# Altium schematic sheet LiPoBattery.SchDoc(LiPoBattery)
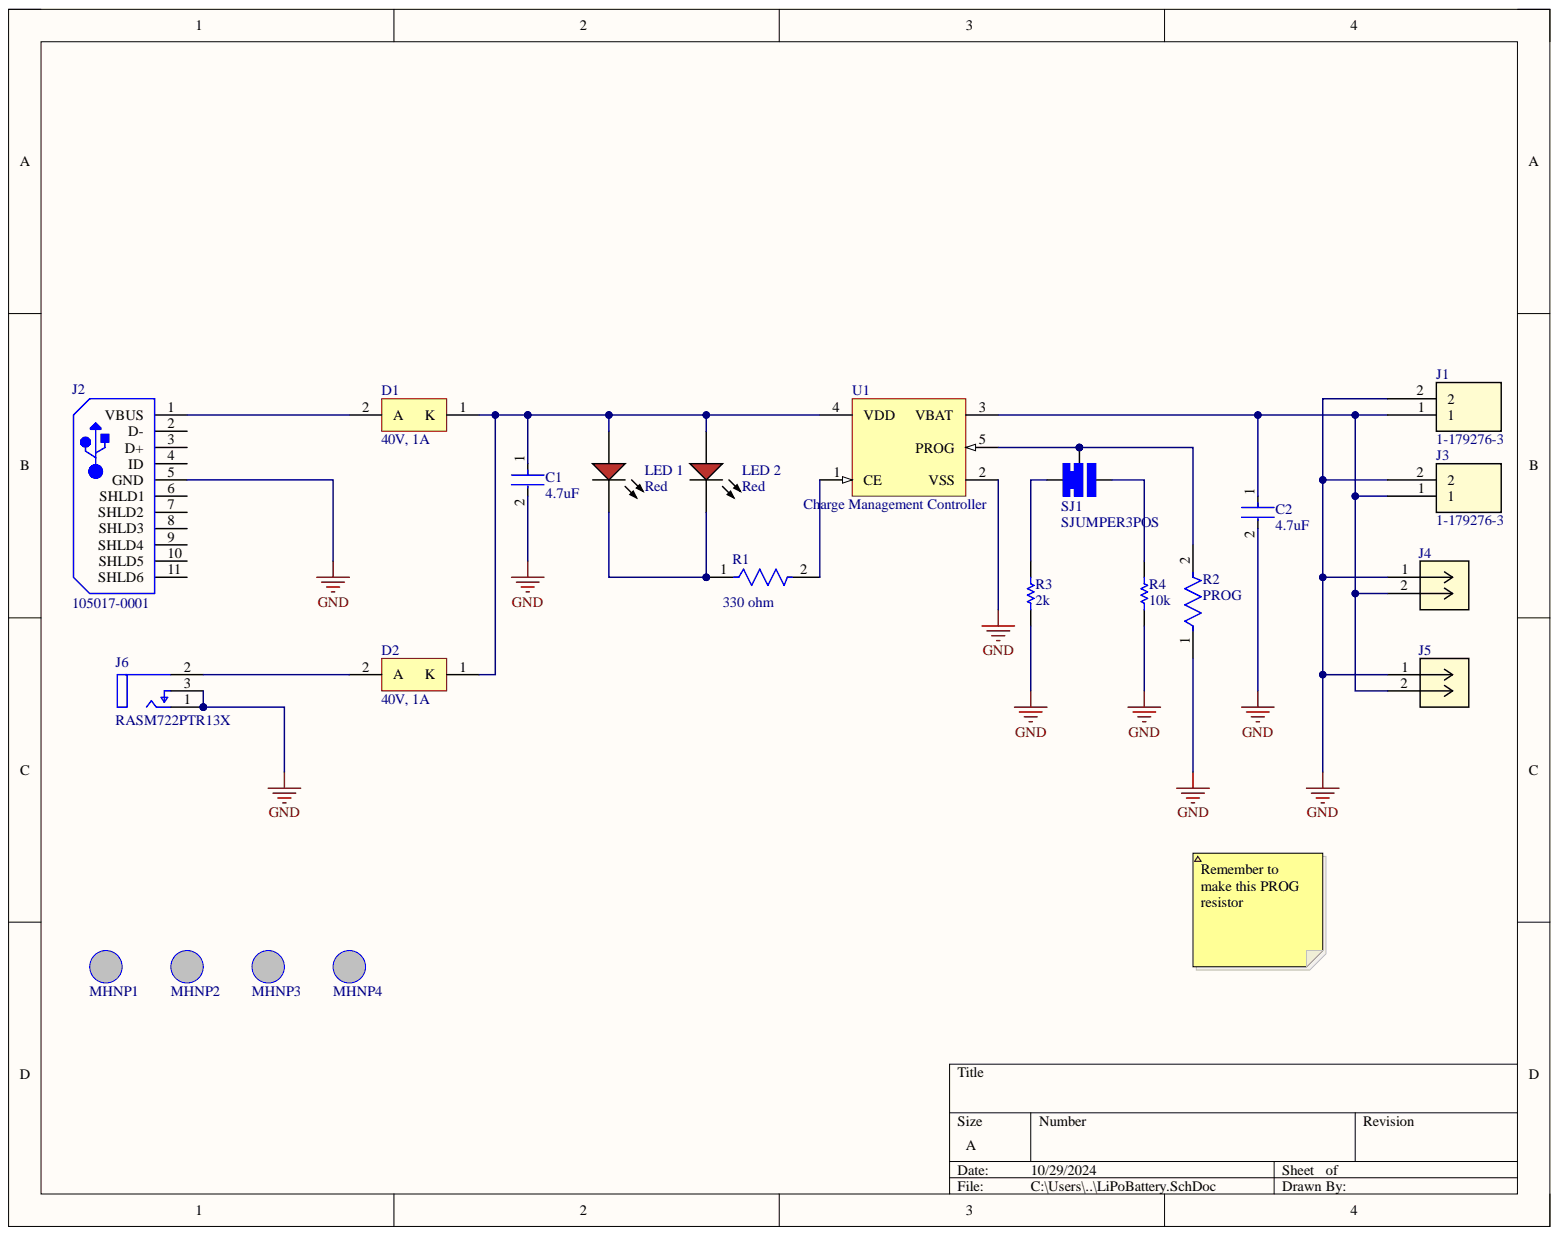
[diagram p0: LiPoBattery.SchDoc]

## Parts
C1  4.7uF  [FP-CC0402-MFG]
C2  4.7uF  [FP-CC0402-MFG]
D1  40V, 1A  [ONSC-SMA-2-403D-02_V]
D2  40V, 1A  [ONSC-SMA-2-403D-02_V]
J1  1-179276-3  [1-179276-3_TYC]
J2  105017-0001  [USB-MICRO-B_V]
J3  1-179276-3  [1-179276-3_TYC]
J4  2POS VET HEADER 0.100*  [2Pins_AMP]  (value not drawn)
J5  2POS VET HEADER 0.100*  [2Pins_AMP]  (value not drawn)
J6  RASM722PTR13X  [FP-RASM722PTR13X-MFG]
LED_1  Red  [VISH-TLMX1100_V]
LED_2  Red  [VISH-TLMX1100_V]
MHNP1  Mounting Hole Non-Plated 3.2mm  [MTHNP3P2MM]  (value not drawn)
MHNP2  Mounting Hole Non-Plated 3.2mm  [MTHNP3P2MM]  (value not drawn)
MHNP3  Mounting Hole Non-Plated 3.2mm  [MTHNP3P2MM]  (value not drawn)
MHNP4  Mounting Hole Non-Plated 3.2mm  [MTHNP3P2MM]  (value not drawn)
R1  330 ohm  [FP-CRCW0805-e3-MFG]
R2  PROG  [FP-MRS25-MFG]
R3  2k  [RESC2013X70X40LL20T20]
R4  10k  [RESC2013X70X40ML20T20]
SJ1  SJUMPER3POS  [SJUMPER3POS-Footprint-1]
U1  Charge Management Controller  [SOT-23-OT5_M]

## Nets
GND: C1.2 C2.2 J1.2 J2.5(GND) J3.2 J4.1 J5.1 J6.1 J6.3 R2.1 R3.1 R4.1 U1.2(VSS)
NetC1_1: C1.1 D1.1 D2.1 LED_1.1 LED_2.1 U1.4(VDD)
NetC2_1: C2.1 J1.1 J3.1 J4.2 J5.2 U1.3(VBAT)
NetD1_2: D1.2 J2.1(VBUS)
NetD2_2: D2.2 J6.2
NetLED 1_2: LED_1.2 LED_2.2 R1.1
NetR1_2: R1.2 U1.1(CE)
NetR2_2: R2.2 SJ1.2 U1.5(PROG)
NetR3_2: R3.2 SJ1.1
NetR4_2: R4.2 SJ1.3

Not connected: J2 2(D-) 3(D+) 4(ID) 6(SHLD1) 7(SHLD2) 8(SHLD3) 9(SHLD4) 10(SHLD5) 11(SHLD6)

## Library data of the parts
C1 C2 = CMP-03422-001389-1: CAP CER 4.7UF 6.3V X5R 0402; Manufacturer: YAGEO; Manufacturer Part Number: CC0402KRX5R5BB475; Automotive Grade: NA; Material: Ceramic; Temperature Coefficient: 30ppm/°C; Tolerance: 0.1; Automotive: No; Capacitance: 4.7 uF; Category: Cap; Component Kind: Standard; ComponentLink2Description: Datasheet; ComponentLink2URL: http://www.yageo.com/documents/recent/UPY-GP_NP0_16V-to-50V_16.pdf; Device Class L1: Passive Components; Device Class L2: Capacitors; Device Class L3: Ceramic Capacitors; Digikey Description: CAP CER 4.7UF 6.3V X5R 0402; Footprint Url: http://www.yageo.com/exep/pages/download/literatures/UPY-C_GEN_24.pdf; Height: 0.55mm; Ipc Land Pattern Name: CAPC10050X50; Lead Free: Yes; Library Name: Altium Content Vault; Mouser Description: 0402 4.7 uF 6.3 V ±10% Tolerance X5R SMT Multilayer Ceramic Capacitor; Octopart: https://octopart.com/cc0402krx5r5bb475-yageo-71498076; Package: CC0402; RoHS: TRUE; Temperature: -55°C to +125°C; Temperature Characteristic: X5R; Temperature Range High: +125°C; Temperature Range Low: -55°C; Value: 4.7 uF; Voltage Rating: 6.3 V
D1 D2 = CMP-1055-00320-1: Schottky Power Rectifier, 2-Pin SMA, Pb-Free, Tape and Reel; Manufacturer: On Semiconductor; PartNumber: MBRA140T3G; Configuration: Single; IFSM Max (A): 30; Component Kind: Standard; ComponentLink1Description: Manufacturer URL; ComponentLink1URL: http://www.onsemi.com/; ComponentLink2Description: Datasheet; ComponentLink2URL: http://www.onsemi.cn/pub_link/Collateral/MBRA140T3-D.PDF; ComponentLink3Description: Package Specification; ComponentLink3URL: http://www.onsemi.com/pub_link/Collateral/403D-02.PDF; DatasheetVersion: Rev. 10; Library Name: Altium Content Vault; Mounting Technology: Surface Mount; PackageDescription: 2-Pin Small Molded Package, J-Bend Lead, Body 2.605 x 4.315 mm; PackageReference: SMA-2-403D-02; PackageVersion: Rev. G, 07/2012; Packing: Tape and Reel; RoHS: TRUE
J1 J3 = CMP-006-000002-1: No Description Available; Mfr_Name: TE Connectivity; Component Kind: Standard; Design Item ID: 1-179276-3; Library Name: LiPoBattery; RefDes: RefDes; Source: 1-179276-3(2).SchLib; Type: Type
J2 = CMP-2000-05827-1: Micro-USB B Receptacle, Right Angle, Bottom Mount, Surface Mount, with Solder Tabs, -30 to 85 degC, 5-Pin USB, RoHS, Tape and Reel; Manufacturer: Molex; 3D Model: 105017-0001.stp; Catalog Drawings: 1050170001; Circuits: 5; Connector Type: USB-microB; Featured Product: Micro-USBConnectorsUSBConnectors; Mating Products: WM17145-ND-CABLEMICROUSBBTOSTDA1.0MWM17147-ND-CABLEMICROUSBBTOSTDA2.0MWM17146-ND-CABLEMICROUSBBTOSTDA1.5M; Online Catalog: Molex-MicroUSB; Other Names: WM1399DKR; Package Version: Rev. F, 12/2012; PCN Assembly/Origin: ResinSupplier21/Oct/2015; Voltage - Max: 30V; Category: Connectors,Interconnects; Component Kind: Standard; Datasheet URL: http://www.molex.com/elqNow/elqRedir.htm?ref=http://www.molex.com/webdocs/datasheets/pdf/en-us/1050170001_IO_CONNECTORS.pdf; Datasheet Version: 03/2016; Family: USB,DVI,HDMIConnectors; Features: Shielded,SolderRetention; Gender: Receptacle; Ingress Protection: -; Library Name: Altium Content Vault; Manufacturer URL: http://www.molex.com/; Mounting Technology: SurfaceMount; Mounting Type: BoardCutout,SurfaceMount,RightAngle; Number of Contacts: 5; Package Description: 5-Leads USB, Micro B, Body 7.5 x 5 mm, Pitch 0.65 mm; Package Reference: MICRO-B; Package URL: http://www.molex.com/pdm_docs/sd/1050170001_sd.pdf; Packaging: Digi-Reel®; RoHS Information: 1050170001CertofCompliance; Series: -; Specifications: -; Standard Package: 1
J4 J5 = 67997-402HLF: Connector Header Through Hole 2 position; Mfr_Name: Amphenol ICC; Component Kind: Standard; Library Name: LiPoBattery.SchLib; RefDes: RefDes; Supplier 1: Digikey; Supplier Part Number 1: 609-5502-ND; Type: Type
J6 = CMP-47995-000002-1: CONN PWR JACK 2.1X5.5MM SOLDER; Current Rating: 5A; Gender: Male; Shielding: Unshielded; Case/Package: SMD/SMT; Color: Black; Component Kind: Standard; Connector Type: Jack; Contact Material: Copper Alloy; Contact Plating: Tin; Housing Color: Black; Housing Material: Thermoplastic; Library Name: Altium Content Vault; Mount: Surface Mount; Package Quantity: 120; Termination: Solder
LED_1 LED_2 = CMP-1666-00027-1: LED, RED, 2.1 V, -40 to 100 degC, 2-Pin SMD (0603), RoHS, Tape and Reel; Capacitance: 12 pF; Resistance: 100000000000 Ohm; Tolerance: 5%; Type (Capacitors): C0G; Voltage Rating: 50 V; Case Code (Imperial): 0603; Case Code (Metric): 1608; Case/Package: 0603; Component Kind: Standard; ComponentLink1Description: Manufacturer URL; ComponentLink1URL: http://www.vishay.com/; ComponentLink2Description: Datasheet; ComponentLink2URL: http://www.vishay.com/docs/83173/tlmb1100.pdf; DatasheetVersion: Rev. 2.7, 03/2016; Height: 0.8 mm; Length: 1.6 mm; Library Name: Altium Content Vault; Max Operating Temperature: 125degC; Min Operating Temperature: -55degC; Mount: Surface Mount; Package Quantity: 4000; PackageDescription: 2-Pin Surface Mount Device, Body 1.6 x 0.8 mm; PackageReference: TLMX1100; Packaging: Tape and Reel; Pins: 2; Radiation Hardening: No; REACH SVHC: No SVHC; RoHSCompliant: True; Voltage Rating (DC): 50 V; Width: 0.8 mm
MHNP1-MHNP4 = MTHNP3P2MM: Mounting Hole Non-Plated 3.2mm drill hole; Component Kind: Standard; Library Name: LiPoBattery.SchLib
R1 = CMP-2001-03823-2: RES Thick Film, 330Ω, 1%, 0.125W, 100ppm/°C, 0805; Max Power Dissipation: 125mW; Resistance: 330R; Voltage Rating: 150V; Case Code (Imperial): 0805; Case Code (Metric): 2012; Case/Package: 0805; Component Kind: Standard; Composition: Thick Film; Contact Plating: Tin; Depth: 1.25mm; Features: Automotive AEC-Q200; Height: 0.02inch; Lead Free: Lead Free; Length: 2mm; Library Name: Altium Content Vault; Max Operating Temperature: 155°C; Military Standard: Not; Min Operating Temperature: -55°C; Mount: Surface Mount; Number of Terminations: 2; Package Quantity: 10; Packaging: Tape and Reel; Power Rating: 125mW; Temperature Coefficient: 100ppm/°C; Termination: SMD/SMT; Tolerance: 1%; Voltage Rating (DC): 150V; Weight: 0.000194oz; Width: 0.049inch
R2 = CMP-00030-42757237-1: MRS25000C1000FRP00 Resistor, 100 Ohm, ± 1%, 600 mW, Axial Leaded, Metal Film (Thin Film), Professional; Lead Diameter: 600µm; Max Power Dissipation: 600mW; Resistance: 100Ω; Temperature Coefficient: 50ppm/°C; Voltage Rating: 350V; Case/Package: Axial; Component Kind: Standard; Composition: Metal Film, Thin Film; Contact Plating: Tin; Diameter: 2.5mm; Lead Free: Lead Free; Length: 6.5mm; Library Name: Altium Content Vault; Max Operating Temperature: 155°C; Military Standard: Not; Min Operating Temperature: -55°C; Mount: Through Hole; NexarPartId: 42757237; Number of Pins: 2; Number of Terminations: 2; Packaging: Tape & Reel (TR); Power Rating: 600mW; Radiation Hardening: No; REACH SVHC: Unknown; RoHS: Compliant; Schedule B: 8533210090, 8533210090; Termination: Axial; Tolerance: 1%; Voltage Rating (DC): 350V; Width: 2.5mm
R3 = CMP-2001-00483-1: Manufacturer: Panasonic; Part Number: ERJ-P06J202V; Component Kind: Standard; Library Name: Altium Content Vault
R4 = CMP-2001-00134-1: Manufacturer: Panasonic; Part Number: ERJ-6ENF3322V; Component Kind: Standard; Library Name: Altium Content Vault
SJ1 = CMP-001-0000-3: Solder jumber 3 pads and pins; Component Kind: Standard; Library Name: LiPoBattery; Pins: 3
U1 = CMP-0133-00139-1: Simple, Miniature Single-Cell, Fully Integrated Li-Ion/Li-Polymer Charge Management Controller, 4.2V, 5-Pin SOT-23, Industrial Temperature, Tape and Reel; Code_IPC: SOT23-5; Component Kind: Standard; DatasheetVersion: revB, Sep-2007; Library Name: Altium Content Vault; PackageDescription: 5-Lead Plastic Small Outline Transistor (OT) [SOT-23]; PackageReference: SOT-23-OT5; PackageVersion: revBB, Aug-2009
